# Altium schematic sheet Connectors.SchDoc(Connectors)
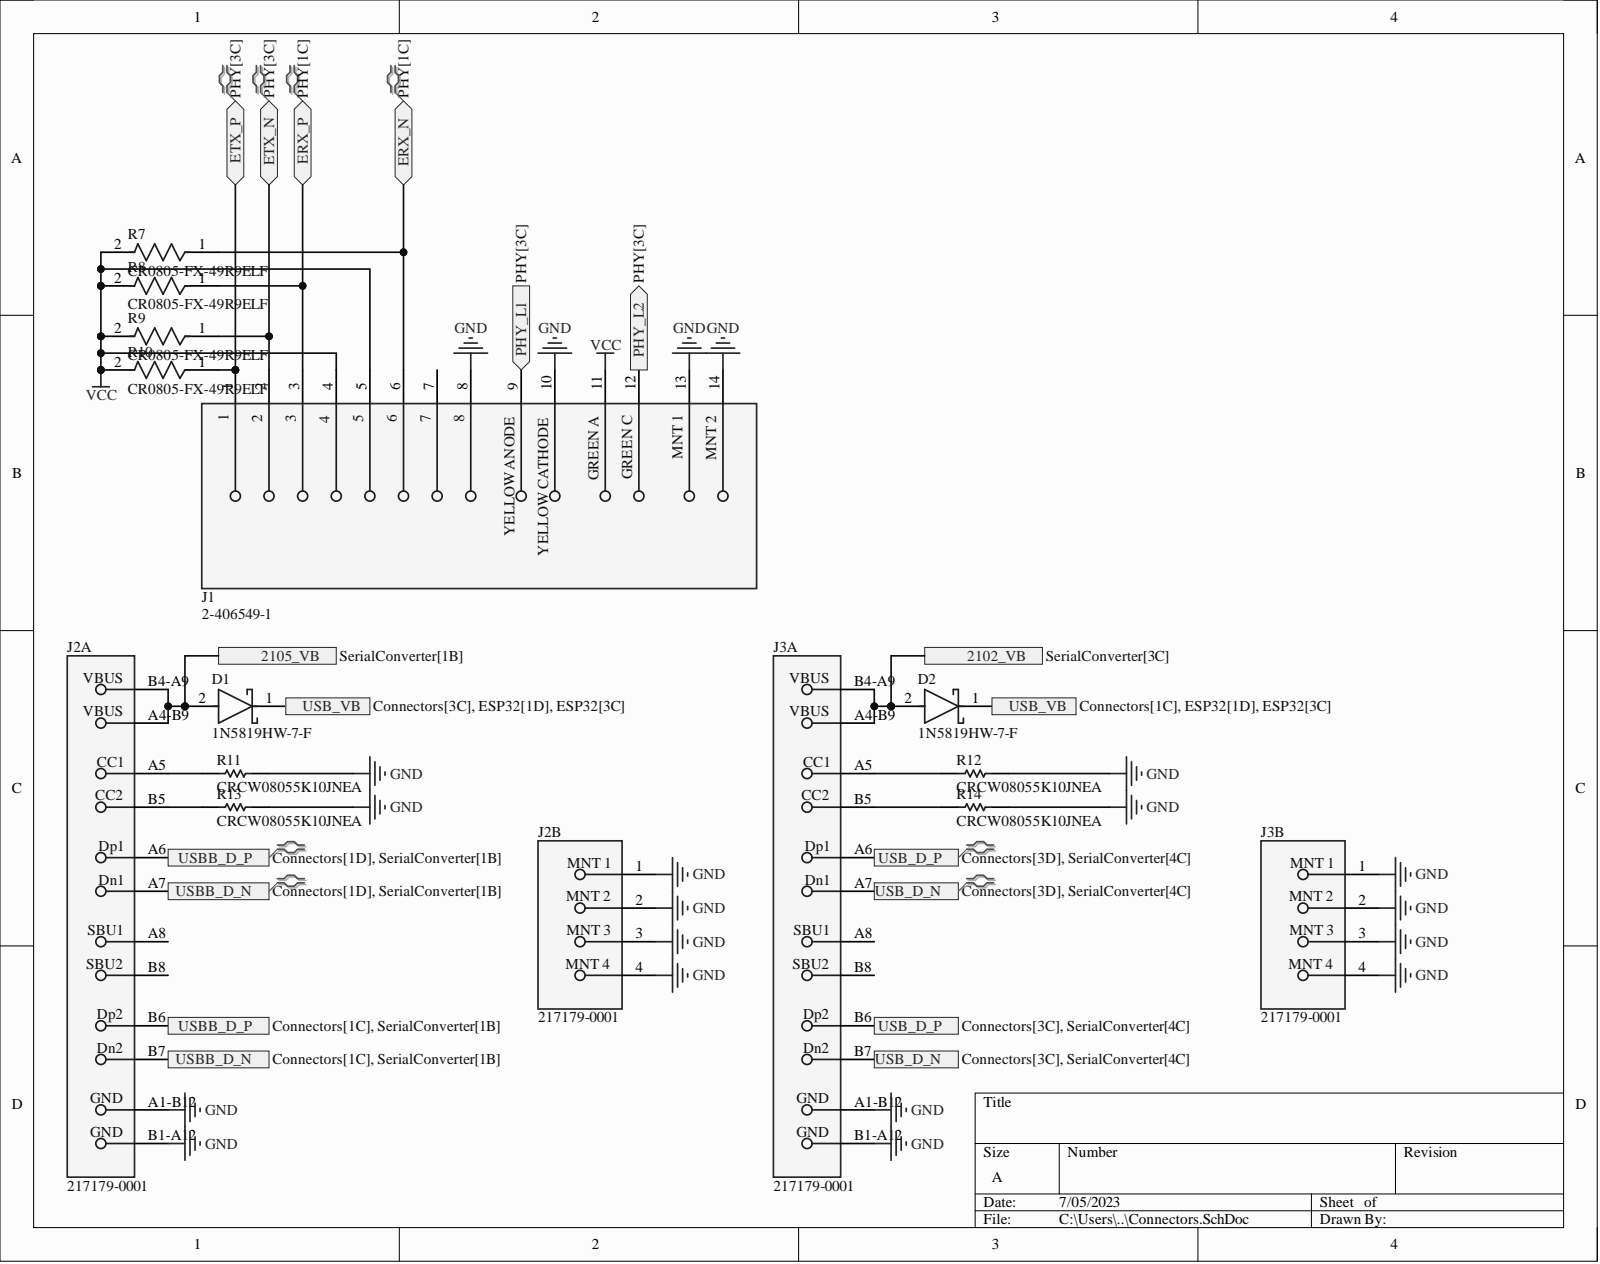
[diagram p0: Connectors.SchDoc]

## Parts
D1  1N5819HW-7-F  [FP-SOD123-IPC_A]
D2  1N5819HW-7-F  [FP-SOD123-IPC_A]
J1  2-406549-1  [FP-2-406549-1-MFG]
J2  217179-0001  [FP-217179-0001-MFG]  (parts A,B)
J3  217179-0001  [FP-217179-0001-MFG]  (parts A,B)
R7  CR0805-FX-49R9ELF  [FP-CR0805-MFG]
R8  CR0805-FX-49R9ELF  [FP-CR0805-MFG]
R9  CR0805-FX-49R9ELF  [FP-CR0805-MFG]
R10  CR0805-FX-49R9ELF  [FP-CR0805-MFG]
R11  CRCW08055K10JNEA  [RESC2013X50X30LL20T20]
R12  CRCW08055K10JNEA  [RESC2013X50X30LL20T20]
R13  CRCW08055K10JNEA  [RESC2013X50X30LL20T20]
R14  CRCW08055K10JNEA  [RESC2013X50X30LL20T20]

## Ports
2102_VB, 2105_VB, ERX_N, ERX_P, ETX_N, ETX_P, PHY_L1, PHY_L2, USB_D_N, USB_D_P, USB_VB, USBB_D_N, USBB_D_P

## Nets
GND: J1.8 J1.10 J1.13 J1.14 J2.1 J2.2 J2.3 J2.4 J2.A1-B12 J2.B1-A12 J3.1 J3.2 J3.3 J3.4 J3.A1-B12 J3.B1-A12 R11.2 R12.2 R13.2 R14.2
VCC: J1.4 J1.5 J1.11 R7.2 R8.2 R9.2 R10.2
2102_VB: D2.2 J3.A4-B9 J3.B4-A9 ; port 2102_VB
2105_VB: D1.2 J2.A4-B9 J2.B4-A9 ; port 2105_VB
ERX_N: J1.6 R7.1 ; port ERX_N
ERX_P: J1.3 R8.1 ; port ERX_P
ETX_N: J1.2 R9.1 ; port ETX_N
ETX_P: J1.1 R10.1 ; port ETX_P
PHY_L1: J1.9 ; port PHY_L1
PHY_L2: J1.12 ; port PHY_L2
USB_D_N: J3.A7 J3.B7 ; port USB_D_N
USB_D_P: J3.A6 J3.B6 ; port USB_D_P
USB_VB: D1.1 D2.1 ; port USB_VB
USBB_D_N: J2.A7 J2.B7 ; port USBB_D_N
USBB_D_P: J2.A6 J2.B6 ; port USBB_D_P
NetJ2_A5: J2.A5 R11.1
NetJ2_B5: J2.B5 R13.1
NetJ3_A5: J3.A5 R12.1
NetJ3_B5: J3.B5 R14.1

Not connected: J1 7; J2 A8 B8; J3 A8 B8

## Library data of the parts
D1 D2 = CMP-0303-00003-3: DIODE SCHOTTKY 40V 1A SOD123; Capacitance: 110pF; Element Configuration: Single; Forward Voltage: 450mV; Max Forward Surge Current (Ifsm): 25A; Max Power Dissipation: 450mW; Peak Reverse Current: 50uA; Polarity: Standard; Average Rectified Current: 1A; Case/Package: SOD-123-2; Component Kind: Standard; Contact Plating: Tin; Current Rating: 1A; Forward Current: 1A; Height: 1.25mm; Lead Free: Lead Free; Length: 2.85mm; Library Name: Altium Content Vault; Max Operating Temperature: 125°C; Max Repetitive Reverse Voltage (Vrrm): 40V; Max Reverse Voltage (DC): 40V; Min Operating Temperature: -65°C; Number of Pins: 2; Output Current: 1A; Package Quantity: 3000; Packaging: Tape and Reel; Peak Non-Repetitive Surge Current: 25A; Radiation Hardening: No; REACH SVHC: No SVHC; Termination: SMD/SMT; Voltage Rating (DC): 40V; Weight: 0.000353oz; Width: 1.7mm
J1 = CMP-2000-05541-2: CONN MOD JACK 8P8C R/A SHIELDED; Approvals: UL; Current Rating: 1.5A; Direction: Up; Element Configuration: Single; Gender: Female; Keyed: No; Max Voltage Rating (AC): 150V; Number of Contacts: 8; Number of Ports: 1; PCB Mounting Orientation: Right Angle; PCB Thickness: 1.57mm; Pitch: 2.03mm; Tail Length: 2.54mm; Color: Yellow; Component Kind: Standard; Connector Type: Jack; Contact Material: Bronze; Contact Plating: Gold; Depth: 15.75mm; Flammability Rating: UL94 V-0; Housing Color: Black; Housing Material: Thermoplastic; Length: 17.86mm; Library Name: Altium Content Vault; Max Operating Temperature: 85°C; Min Operating Temperature: -40°C; Mount: Panel; Number of Positions: 8; Number of Positions Loaded: 8; Number of Rows: 1; Orientation: Right Angle; Package Quantity: 63; Packaging: Tray; Radiation Hardening: No; RoHS Compliant: Yes; Series: -; Shielding: Shielded; Termination: Solder; Voltage Rating: 150V; Weight: 0.144729oz; Width: 17.27mm
J2 J3 = CMP-04767-000028-1: CONN RCP USB2.0 TYP C 24P SMD RA; Component Kind: Standard; Library Name: Altium Content Vault
R7-R10 = CMP-07231-001472-1: Thick Film Chip Resistors 0805 49.9Ω 0.125W 1% 100ppm/°C; Power Rating: 125mW; Resistance: 49.9R; Voltage Rating: 150V; Case Code (Imperial): 0805; Case Code (Metric): 2012; Case/Package: 0805; Component Kind: Standard; Composition: Thick Film; Contact Plating: Tin; Depth: 1.25mm; Height: 0.5mm; Length: 2mm; Library Name: Altium Content Vault; Max Operating Temperature: 155°C; Military Standard: Not; Min Operating Temperature: -55°C; Mount: Surface Mount; Number of Pins: 2; Package Quantity: 5000; Packaging: Tape and Reel; Radiation Hardening: No; REACH SVHC: No SVHC; Temperature Coefficient: 100ppm/°C; Termination: SMD/SMT; Thickness: 508um; Tolerance: 1%; Voltage Rating (DC): 150V; Width: 1.25mm
R11-R14 = CMP-2001-04025-1: Manufacturer: Vishay Dale; Component Kind: Standard; Library Name: Altium Content Vault; Part Number: CRCW08055K10JNEA
